# Altium schematic sheet DE-080324.SchDoc(DE-080324)
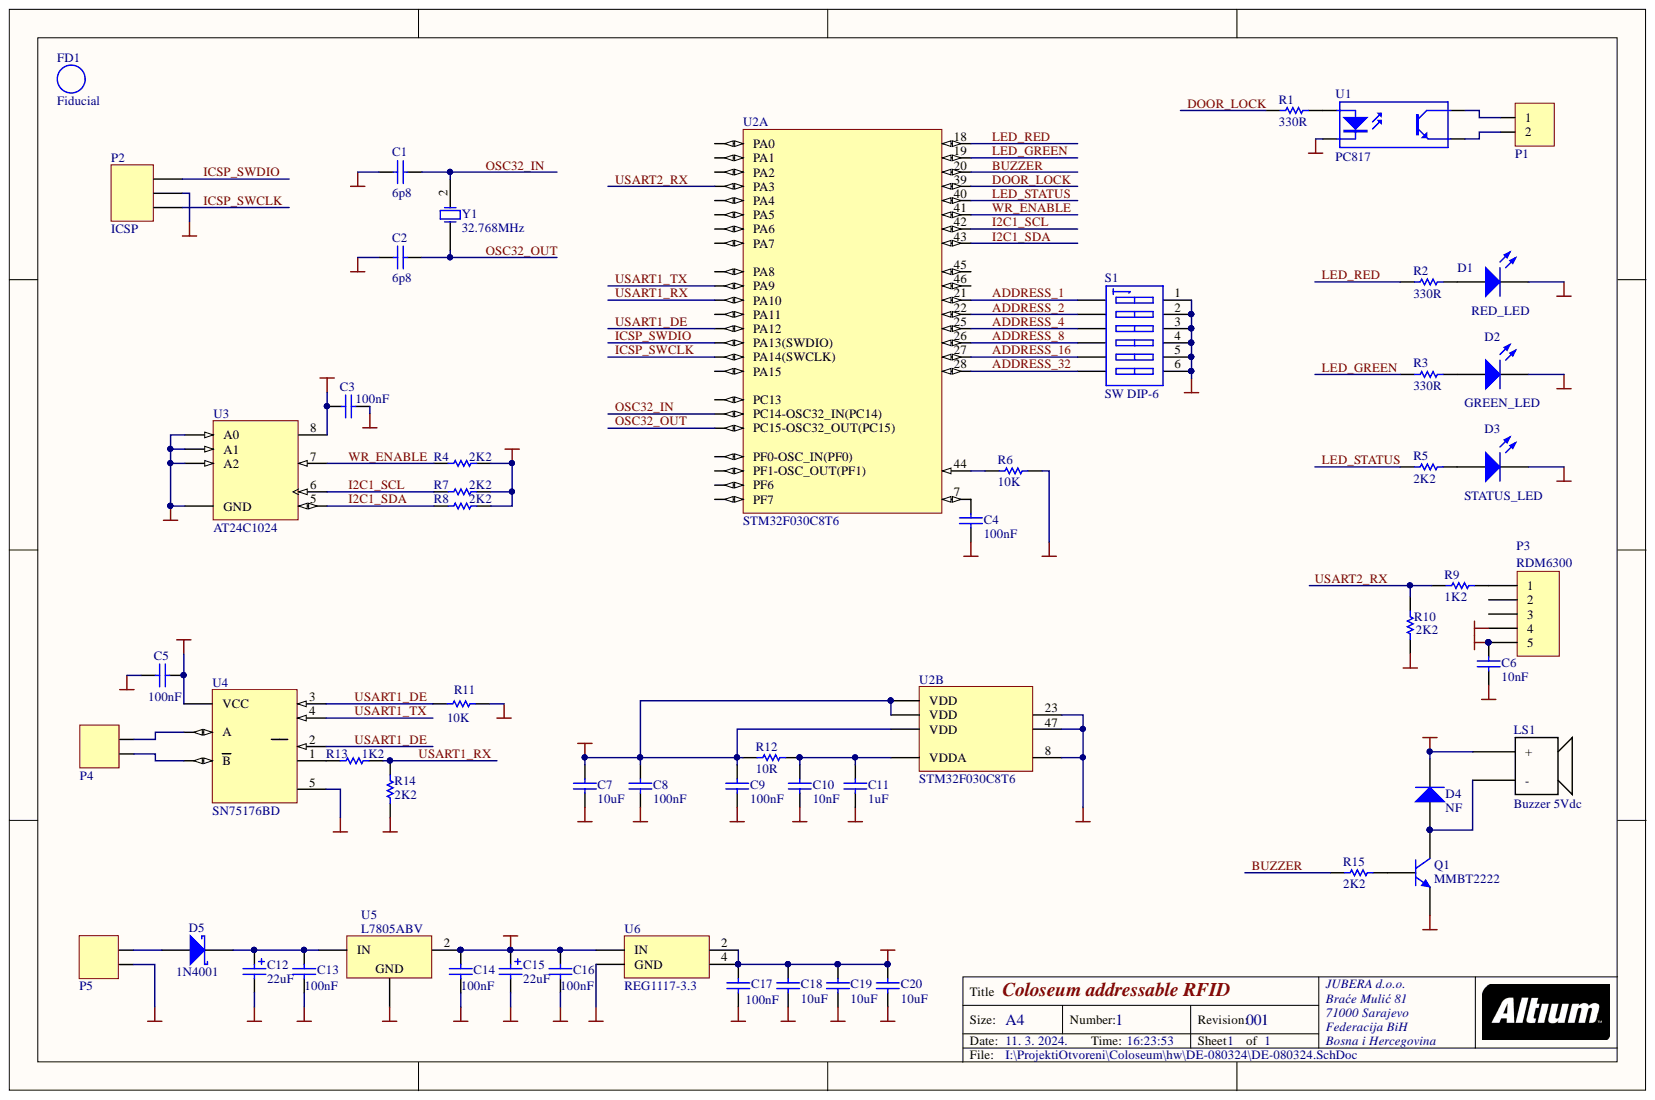
[diagram p0: DE-080324.SchDoc]

## Parts
C1  6p8  [0603]
C2  6p8  [0603]
C3  100nF  [0603]
C4  100nF  [0603]
C5  100nF  [0603]
C6  10nF  [0603]
C7  10uF  [0805]
C8  100nF  [0603]
C9  100nF  [0603]
C10  10nF  [0603]
C11  1uF  [0603]
C12  22uF  [CAPPR2-5X11]
C13  100nF  [0603]
C14  100nF  [0603]
C15  22uF  [CAPPR2-5X11]
C16  100nF  [0603]
C17  100nF  [0603]
C18  10uF  [0805]
C19  10uF  [0805]
C20  10uF  [0805]
D1  RED_LED  [2.0X1.25X1.2]
D2  GREEN_LED  [2.0X1.25X1.2]
D3  STATUS_LED  [2.0X1.25X1.2]
D4  NF  [SOD323]
D5  1N4001  [SMB]
FD1  Fiducial  [Fiducial]  (no BOM)
LS1  Buzzer 5Vdc  [BUZZER]
P1  TERMINAL BLOK 2  [TERMINAL BLOK 2]  (value not drawn)
P2  ICSP  [HDR1X3]
P3  RDM6300  [HDR1X5]
P4  TERMINAL BLOK 2  [TERMINAL BLOK 2]  (value not drawn)
P5  TERMINAL BLOK 2  [TERMINAL BLOK 2]  (value not drawn)
Q1  MMBT2222  [SOT23_N]
R1  330R  [ERA-0603]
R2  330R  [ERA-0603]
R3  330R  [ERA-0603]
R4  2K2  [ERA-0603]
R5  2K2  [ERA-0603]
R6  10K  [ERA-0603]
R7  2K2  [ERA-0603]
R8  2K2  [ERA-0603]
R9  1K2  [ERA-0603]
R10  2K2  [ERA-0603]
R11  10K  [ERA-0603]
R12  10R  [ERA-0603]
R13  1K2  [ERA-0603]
R14  2K2  [ERA-0603]
R15  2K2  [ERA-0603]
S1  SW DIP-6  [DIP-12/SW]
U1  PC817  [SOP4]
U2  STM32F030C8T6  [STM-LQFP48_N]  (parts A,B)
U3  AT24C1024  [8A2_L]
U4  SN75176BD  [D008_L]
U5  L7805ABV  [TO220ABN]
U6  REG1117-3.3  [ZZ311]
Y1  32.768MHz  [R26]

## Nets
+3V3: C3.1 C7.2 C8.2 C9.2 C17.2 C18.2 C19.2 C20.2 R4.2 R7.2 R8.2 R12.1 U2.1(VDD) U2.24(VDD) U2.48(VDD) U3.8 U6.2 U6.4
+5V: C5.2 C6.2 C14.2 C15.1 C16.2 D4.1 LS1.1 P3.5 U4.8(VCC) U5.2 U6.3(IN)
VSS: C1.2 C2.2 C3.2 C4.1 C5.1 C6.1 C7.1 C8.1 C9.1 C10.1 C11.1 C12.2 C13.1 C14.1 C15.2 C16.1 C17.1 C18.1 C19.1 C20.1 D1.2 D2.2 D3.2 P2.2 P3.4 P5.1 Q1.2 R6.2 R10.1 R11.2 R14.1 S1.1 S1.2 S1.3 S1.4 S1.5 S1.6 U1.2 U2.8 U2.23 U2.47 U3.1(A0) U3.2(A1) U3.3(A2) U3.4(GND) U4.5 U5.3 U6.1(GND)
ADDRESS_1: S1.12 U2.21
ADDRESS_2: S1.11 U2.22
ADDRESS_4: S1.10 U2.25
ADDRESS_8: S1.9 U2.26
ADDRESS_16: S1.8 U2.27
ADDRESS_32: S1.7 U2.28
BUZZER: R15.1 U2.20
DOOR_LOCK: R1.1 U2.39
I2C1_SCL: R7.1 U2.42 U3.6
I2C1_SDA: R8.1 U2.43 U3.5
ICSP_SWCLK: P2.1 U2.37(PA14(SWCLK))
ICSP_SWDIO: P2.3 U2.34(PA13(SWDIO))
LED_GREEN: R3.1 U2.19
LED_RED: R2.1 U2.18
LED_STATUS: R5.1 U2.40
OSC32_IN: C1.1 U2.3(PC14-OSC32_IN(PC14)) Y1.2
OSC32_OUT: C2.1 U2.4(PC15-OSC32_OUT(PC15)) Y1.1
USART1_DE: R11.1 U2.33(PA12) U4.2 U4.3
USART1_RX: R13.2 R14.2 U2.31(PA10)
USART1_TX: U2.30(PA9) U4.4
USART2_RX: R9.1 R10.2 U2.13(PA3)
WR_ENABLE: R4.1 U2.41 U3.7
NetC4_2: C4.2 U2.7
NetC10_2: C10.2 C11.2 R12.2 U2.9(VDDA)
NetC12_1: C12.1 C13.2 D5.2 U5.1
NetD1_1: D1.1 R2.2
NetD2_1: D2.1 R3.2
NetD3_1: D3.1 R5.2
NetD4_2: D4.2 LS1.2 Q1.3
NetD5_1: D5.1 P5.2
NetP1_1: P1.1 U1.4
NetP1_2: P1.2 U1.3
NetP3_1: P3.1 R9.2
NetP4_1: P4.1 U4.7(B)
NetP4_2: P4.2 U4.6(A)
NetQ1_1: Q1.1 R15.2
NetR1_2: R1.2 U1.1
NetR6_1: R6.1 U2.44
NetR13_1: R13.1 U4.1

Not connected: P3 2 3; U2 2(PC13) 5(PF0-OSC_IN(PF0)) 6(PF1-OSC_OUT(PF1)) 10(PA0) 11(PA1) 12(PA2) 14(PA4) 15(PA5) 16(PA6) 17(PA7) 29(PA8) 32(PA11) 35(PF6) 36(PF7) 38(PA15) 45 46

## Library data of the parts
C1-C6 C8-C11 C13 C14 C16 C17 = C0603: Ceramic Chip Capacitor - Standard; Note: Footprint corresponds to dimensions for bulk cassette packaging. This case size has tightened tolerances.; Simulation: CAP; Signal Integrity: C0603; Published: 17-Jul-2002; PackageReference: 0603; PackageDocument: Mar-2001; Library Name: DE-080324.SchLib; LatestRevisionNote: Silkscreen size reduced.; LatestRevisionDate: 19-Mar-2004; DatasheetDocument: Mar-2001; ComponentLink2URL: http://www.kemet.com/kemet/web/homepage/kechome.nsf/vapubfilesname/F3102TA.pdf/$file/F3102TA.pdf; ComponentLink2Description: Datasheet; ComponentLink1URL: http://www.kemet.com/; ComponentLink1Description: Manufacturer Link; Component Kind: Standard; Code_JEITA: 0603; Code_IPC: 1608 [0603]; Publisher: Altium Limited
C7 C18-C20 = C0805: Ceramic Chip Capacitor - Standard; Note: Footprint corresponds to dimensions for bulk cassette packaging. This case size has tightened tolerances.; Simulation: CAP; Signal Integrity: C0805; Published: 17-Jul-2002; PackageReference: 0805; PackageDocument: Mar-2001; Library Name: DE-080324.SchLib; LatestRevisionNote: Silkscreen size reduced.; LatestRevisionDate: 19-Mar-2004; DatasheetDocument: Mar-2001; ComponentLink2URL: http://www.kemet.com/kemet/web/homepage/kechome.nsf/vapubfilesname/F3102TA.pdf/$file/F3102TA.pdf; ComponentLink2Description: Datasheet; ComponentLink1URL: http://www.kemet.com/; ComponentLink1Description: Manufacturer Link; Component Kind: Standard; Code_JEITA: 0805; Code_IPC: 2012[0805]; Publisher: Altium Limited
C12 C15 = EKA00AA247F00: Aluminum Electrolytic Capacitor, Radial Style with Long Leads: 47uF, 35V; Note: Refer to Manufacturer's Data Sheets for other lead formations; DrillSize: Nominal, Rounded To The Nearest 0.1mm; Value: 47uF; Simulation: CAP; Signal Integrity: EKA00AA247F00; Published: 19-Mar-2004; PackageReference: CAPPR2-5X11; Library Name: DE-080324.SchLib; DatasheetDocument: 20-Feb-2001; ComponentLink2URL: http://www.vishay.com/docs/25014/eka.pdf; ComponentLink2Description: Datasheet; ComponentLink1URL: http://www.vishay.com/; ComponentLink1Description: Manufacturer Link; Component Kind: Standard; Publisher: Altium Limited
D1-D3 = HSMC-C540-F0001: Top Mount AS AlInGaP Red Oval Lens High Brightness; PCB_Layout: Complies with manufacturer's recommendation.; LuminousIntensity: 96mcd (Minimum).; Simulation: Agl_HSMAC540; Signal Integrity: LED; Published: 11-Dec-2002; PackageReference: 2.0X1.25X1.2; PackageDocument: 30-Apr-2002; Library Name: DE-080324.SchLib; LED_Colour: Red; DatasheetDocument: 7-Mar-2002; ComponentLink2URL: http://cp.literature.agilent.com/litweb/pdf/5988-5794EN.pdf; ComponentLink2Description: Datasheet; ComponentLink1URL: http://www.agilent.com/; ComponentLink1Description: Manufacturer Link; Component Kind: Standard; Publisher: Altium Limited
D4 = BAS321: General-purpose Diode; Simulation: BAS321; Signal Integrity: BAS321; Published: 17-Sep-2003; PackageVersion: May-1999; PackageReference: SOD323; PackageDescription: SOD-323/SC-76; 2 Leads; Body 1.7 x 1.3 mm, (LxW); Library Name: DE-080324.SchLib; LatestRevisionNote: Silkscreen size reduced.; LatestRevisionDate: 19-Mar-2004; DatasheetVersion: 9-Feb-1999; ComponentLink2URL: http://www.semiconductors.philips.com/acrobat/datasheets/BAS321_2.pdf; ComponentLink2Description: Datasheet; ComponentLink1URL: http://www.semiconductors.philips.com/; ComponentLink1Description: Manufacturer Link; Component Kind: Standard; Publisher: Altium Limited
D5 = 10BQ100: Schottky Rectifier; Simulation: 10BQ100; Signal Integrity: 10BQ100; Published: 8-Jun-2000; PackageReference: SMB; PackageDescription: DO-214-AA/SMB; 2 C-Bend Leads; Body 5.3 x 3.6 mm, inc. leads (LxW); Library Name: DE-080324.SchLib; LatestRevisionNote: Re-released for DXP Platform.; LatestRevisionDate: 17-Jul-2002; DatasheetVersion: PD-2.437A; ComponentLink2URL: http://www.irf.com/product-info/datasheets/data/10bq100.pdf; ComponentLink2Description: Datasheet; ComponentLink1URL: http://www.irf.com/; ComponentLink1Description: Manufacturer Link; Component Kind: Standard; Publisher: Altium Limited
FD1 = Fiduchial: Fiduchial mark; Library Name: DE-080324.SchLib
LS1 = BUZZER: 5Vdc PCB buzzer; Library Name: DE-080324.SchLib; Component Kind: Standard
P1 P4 P5 = TERMINAL BLOK 2: 2 pinski terminal blok; Library Name: DE-080324.SchLib; Component Kind: Standard
P2 = Header 3: Header, 3-Pin; Signal Integrity: Connector; Library Name: Miscellaneous Connectors.IntLib; LatestRevisionNote: Re-released for DXP Platform.; LatestRevisionDate: 17-Jul-2002; Component Kind: Standard; Publisher: Altium Limited
P3 = Header 5: Header, 5-Pin; Signal Integrity: Connector; Library Name: Miscellaneous Connectors.IntLib; LatestRevisionNote: Re-released for DXP Platform.; LatestRevisionDate: 17-Jul-2002; Component Kind: Standard; Publisher: Altium Limited
Q1 = BC817: NPN General-purpose Transistor; Simulation: QBC817; Signal Integrity: BC817; Published: 17-Sep-2003; PackageVersion: May-1999; PackageReference: SOT23; PackageDescription: 3-Pin SOT-23 Package 0.95 mm Pitch, 2.3 mm Lead Span; Library Name: DE-080324.SchLib; LatestRevisionNote: IPC-7351 Footprint Added.; LatestRevisionDate: 12-Dec-2006; DatasheetVersion: Jun-1999; ComponentLink2URL: http://www.semiconductors.philips.com/acrobat/datasheets/BC817_BC817W_BC337_5.pdf; ComponentLink2Description: Datasheet; ComponentLink1URL: http://www.semiconductors.philips.com/; ComponentLink1Description: Manufacturer Link; Component Kind: Standard; Publisher: Altium Limited
R1-R15 = ERAV: Metal Film Thermosensitive Chip Resistor, 0.56 Ohm to 10k Ohm Range, 5% Tolerance, 0603 Size, 0.063 W; Note: If flow soldering, adjust pad width of PCB Footprint to 0.7 - 0.8 times the width of the resistor.; Simulation: RESISTOR; Signal Integrity: Res; Published: 17-Dec-2003; PackageReference: ERA-0603; PackageDocument: Mar-2003; Library Name: DE-080324.SchLib; LatestRevisionNote: Silkscreen size reduced.; LatestRevisionDate: 19-Mar-2004; ComponentLink2URL: http://www.panasonic.com/industrial/components/pdf/AOF0000CE1.pdf; ComponentLink2Description: Datasheet; ComponentLink1URL: http://www.panasonic.com/industrial/components/resistive_products.htm; ComponentLink1Description: Manufacturer Link; Component Kind: Standard; Code_IPC: 1608 [0603]; Publisher: Altium Limited
S1 = SW DIP-6: DIP Switch, 6 Position, SPST; Simulation: dpsw6; Published: 24-Mar-1999; PackageReference: DIP-12/SW; PackageDescription: DIP Switch; 12 Leads; Library Name: Miscellaneous Devices.IntLib; LatestRevisionNote: Re-released for DXP Platform.; LatestRevisionDate: 17-Jul-2002; Component Kind: Standard; Publisher: Altium Limited
U1 = PC817 optocoupler: 4-Pin Phototransistor Optocoupler; Published: 24-Mar-1999; PackageReference: DIP-4; Library Name: DE-080324.SchLib; LatestRevisionNote: Sch pins 3 & 4 Swapped.; LatestRevisionDate: 04-Mar-2005; DatasheetVersion: Nov-2003; Component Kind: Standard; Publisher: Altium Limited
U2 = STM32F030C8T6: ARM Cortex-M0 32-bit MCU, 64 KB Flash, 8 KB Internal RAM, 39 I/Os, 48-pin LQFP, -40 to 85 degC, Tray; Supply Voltage(Vcc) min (V): 2.4; Operating Frequency(F) (Processor speed) (MHz): 48; Internal RAM Size (kB): 8; I/Os (High Current): 39; FLASH Size (Prog) (kB): 64; Supplier Part Number 3: 511-STM32F030C8T6; Supplier Part Number 2: 06X3647; Supplier Part Number 1: 497-14043-ND; Supplier 3: Mouser; Supplier 2: Newark; Supplier 1: Digi-Key; PartNumber: STM32F030C8T6; Packing: Tray; PackageVersion: Rev. 6, 06/2013; PackageReference: LQFP48; PackageDescription: 48-Pin Low Profile Quad Flat Package, 7 x 7 mm Body, 0.5 mm Pitch; Mounting Technology: Surface Mount; Library Name: STMicroelectronics STM32 F0.IntLib; DatasheetVersion: Rev. 1; Core: ARM Cortex-M0; ComponentLink3URL: http://www.st.com/st-web-ui/static/active/en/resource/technical/document/datasheet/DM00058181.pdf; ComponentLink3Description: Package Specification; ComponentLink2URL: http://www.st.com/st-web-ui/static/active/en/resource/technical/document/datasheet/DM00088500.pdf; ComponentLink2Description: Datasheet; ComponentLink1URL: http://www.st.com/web/en/home.html; ComponentLink1Description: Manufacturer URL; Component Kind: Standard
U3 = AT24C02B-TP25-T: 2Kbit (256 x 8), 2-wire Bus Automotive Serial EEPROM with full memory Write Protect, 2.5V, 8-Pin TSSOP, Tape & Reel; Published: 20-Sep-2010; PackageVersion: revD, Dec-2009; PackageReference: 8A2; PackageDescription: 8-Lead, 4.4 mm Body, Plastic Thin Shrink Small Outline Package (TSSOP); Library Name: Atmel Memory Serial EEPROM.IntLib; DatasheetVersion: 8517C,  Jan-2009; ComponentLink3URL: http://www.atmel.com; ComponentLink3Description: Atmel.com Home; ComponentLink2URL: http://www.atmel.com/dyn/resources/prod_documents/doc8517.pdf; ComponentLink2Description: Datasheet; ComponentLink1URL: http://www.atmel.com/products/serial/default.asp; ComponentLink1Description: Manufacturer Link; Component Kind: Standard; Code_JEDEC: MO-153-AA; Publisher: Altium Limited
U4 = SN75176BD: Differential Bus Transceiver; Note: Receiver Hysteresis of 50mV Typical; Published: 15-May-2002; PCB3D: D008; PackageVersion: Jan-1998; PackageReference: D008; PackageDescription: 8-Pin Small Outline Integrated Circuit 1.27 mm Pitch; Library Name: DE-080324.SchLib; LatestRevisionNote: IPC-7351 Footprint Added.; LatestRevisionDate: 13-Apr-2006; DatasheetVersion: Sep-1989; ComponentLink2URL: http://www-s.ti.com/sc/ds/sn75176b.pdf; ComponentLink2Description: Datasheet; ComponentLink1URL: http://www.ti.com/; ComponentLink1Description: Manufacturer Link; Component Kind: Standard; Code_IPC: SOIC127P600-8; Publisher: Altium Limited
U5 = L7805ABV: Precision 1A Regulator; Note: Tab is connected to pin 3 (GND).; Published: 24-Aug-2001; PackageVersion: Jun-1998; PackageReference: TO220ABN; PackageDescription: TO, Thru-Hole, Vertical, Heatsink Mounted; 3 In-Line Leads; Pitch 2.54 mm; Pin sequence 1, 3, 2; Library Name: ST Power Mgt Voltage Regulator.IntLib; LatestRevisionNote: Re-released for DXP Platform.; LatestRevisionDate: 17-Jul-2002; DatasheetVersion: Nov-1999; ComponentLink2URL: http://www.st.com/stonline/products/literature/ds/2144/l7805ab.pdf; ComponentLink2Description: Datasheet; ComponentLink1URL: http://www.st.com/; ComponentLink1Description: Manufacturer Link; Component Kind: Standard; Publisher: Altium Limited
U6 = REG1117-3.3: 800mA and 1A Low Dropout Positive Regulator 2.85V, 3.3V, 5V, and Adjustable; Published: 8-Jun-2000; PackageVersion: revC; PackageReference: ZZ311; PackageDescription: SOT-223/SC-73; 4 Leads; Body 6.7 x 7.3 mm, inc. leads (LxW max); Library Name: DE-080324.SchLib; LatestRevisionNote: Re-released for DXP Platform.; LatestRevisionDate: 17-Jul-2002; DatasheetVersion: Sep-1997; ComponentLink2URL: http://www-s.ti.com/sc/ds/REG1117.pdf; ComponentLink2Description: Datasheet; ComponentLink1URL: http://www.ti.com/; ComponentLink1Description: Manufacturer Link; Component Kind: Standard; Publisher: Altium Limited
Y1 = R26-32.768: Tuning Fork Crystal Unit; Simulation: R26-32.768; Published: 8-Jun-2000; PackageReference: R26; Library Name: DE-080324.SchLib; LatestRevisionNote: Re-released for DXP Platform.; LatestRevisionDate: 17-Jul-2002; DatasheetDocument: 28-Sep-1998; ComponentLink2URL: http://www.raltron.com/products/pdfspecs/crystal_r38_26_145.pdf; ComponentLink2Description: Datasheet; ComponentLink1URL: http://www.raltron.com/products/microcrystals/default.asp; ComponentLink1Description: Manufacturer Link; Component Kind: Standard; Publisher: Altium Limited
